# Altium schematic sheet sEMG_Microcontroller.SchDoc
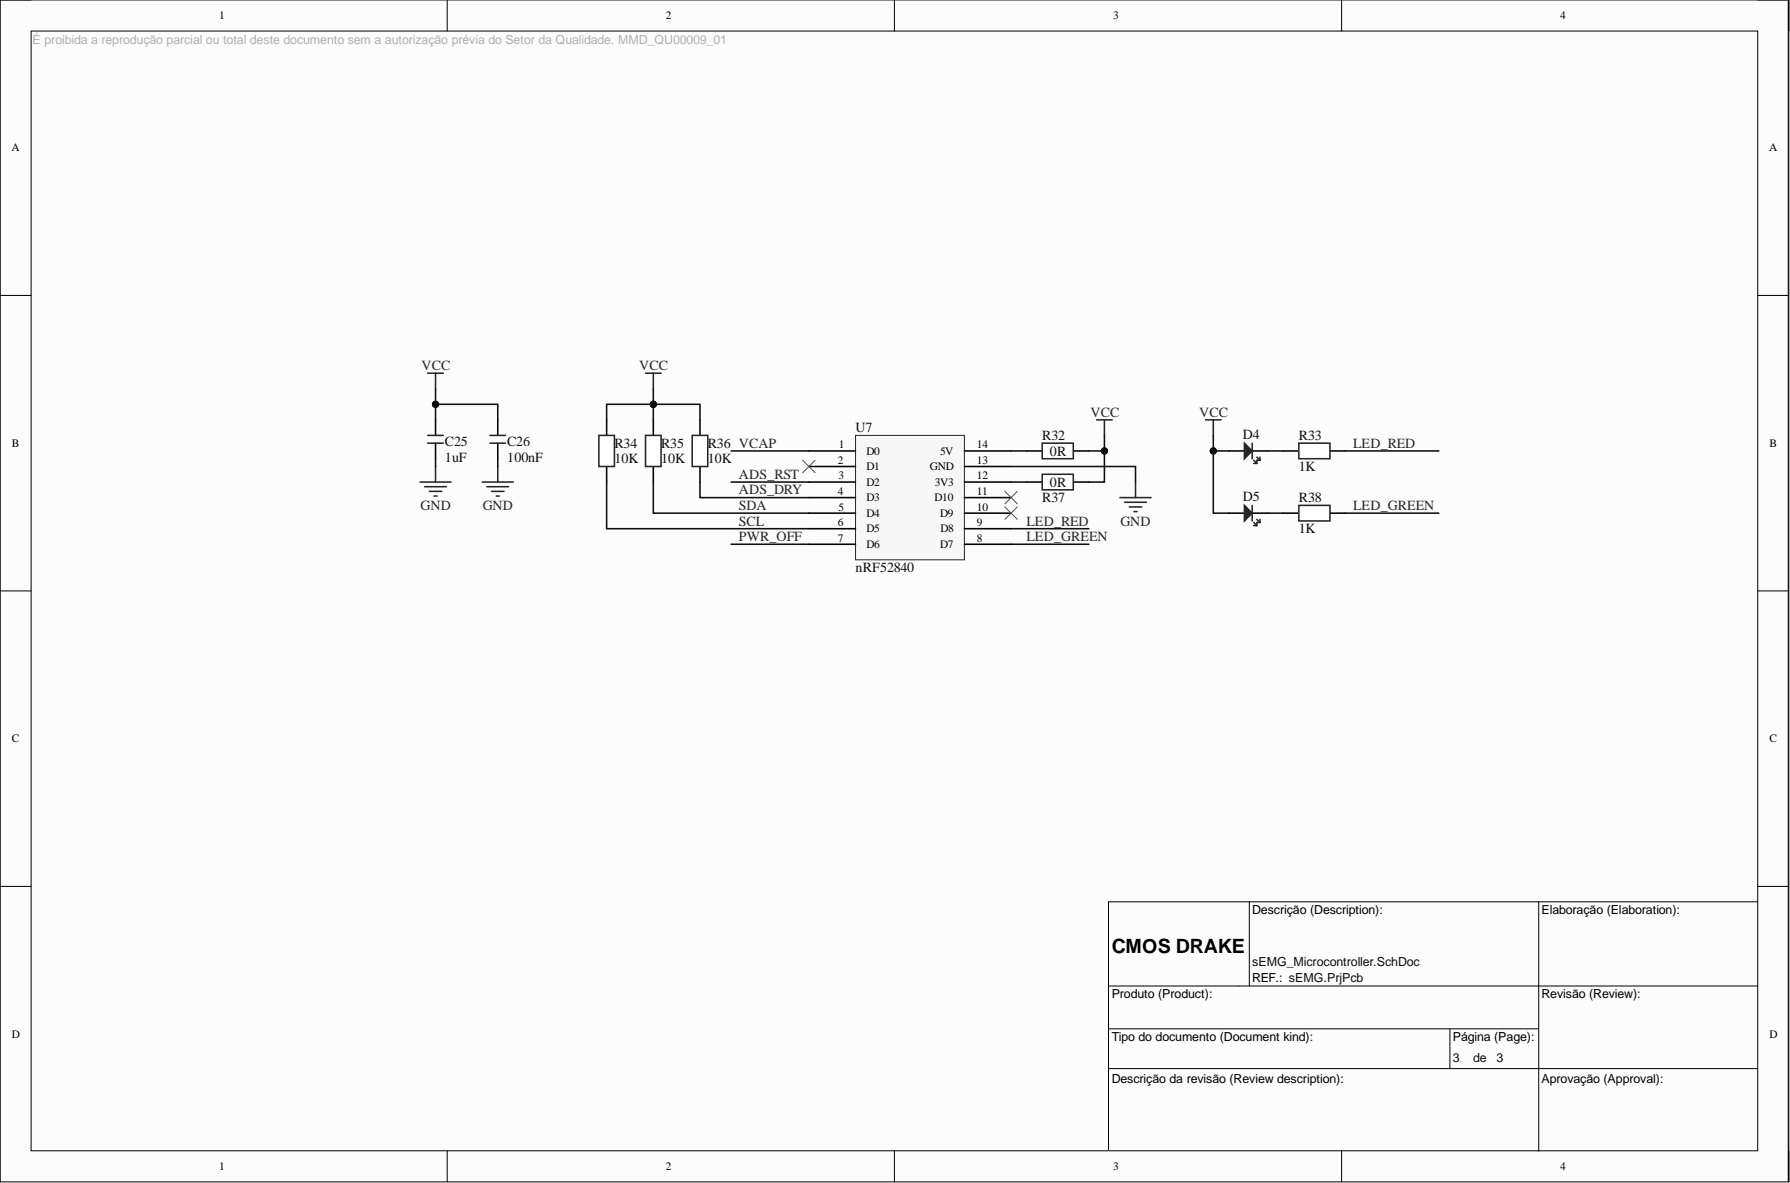
[diagram p0: sEMG_Microcontroller.SchDoc]

## Parts
C25  1uF  [CAP0402[1005]MD]
C26  100nF  [CAP0603[1608]MD-BO]
D4  (no value)  [LEDP0603RED-BO]
D5  (no value)  [LEDP0603GREEN-BO]
R32  0R  [RES0603[1608]MD-BO]
R33  1K  [RES0805[2012]MD]
R34  10K  [RES0805[2012]MD]
R35  10K  [RES0805[2012]MD]
R36  10K  [RES0805[2012]MD]
R37  0R  [RES0603[1608]MD-BO]
R38  1K  [RES0805[2012]MD]
U7  nRF52840  [PCBComponent_1]

## Nets
GND: C25.2 C26.2 U7.13(GND)
VCC: C25.1 C26.1 D4.2 D5.2 R32.2 R34.1 R35.1 R36.1 R37.2
ADS_DRY: R36.2 U7.4(D3)
ADS_RST: U7.3(D2)
LED_GREEN: R38.2 U7.8(D7)
LED_RED: R33.2 U7.9(D8)
PWR_OFF: U7.7(D6)
SCL: R34.2 U7.6(D5)
SDA: R35.2 U7.5(D4)
VCAP: U7.1(D0)
NetD4_1: D4.1 R33.1
NetD5_1: D5.1 R38.1
NetR32_1: R32.1 U7.14(5V)
NetR37_1: R37.1 U7.12(3V3)

Not connected: U7 2(D1) 10(D9) 11(D10)

## Library data of the parts
C25 = SMD 0402 1uF 16V 10% X5R: CERAMIC SMD 0402 1uF 16V 10% X5R; Manufacturer 1: TDK; Part Number 1: C1005X5R1C105K; Component Kind: Standard; Library Name: Capacitors.SCHLIB; Manufacturer 2: Taiyo Yuden; Manufacturer 3: AVX; Part Number 2: EMK105BJ105KV-F; Part Number 3: 0402YD105KAT2A
C26 = SMD 0603 100nF 50V 5% X7R: CERAMIC SMD 0603 100nF 50V 5% X7R; Manufacturer 1: Samsung Electro-Mechanics America, Inc.; Part Number 1: CL10B104JB8NNNC; Component Kind: Standard; Library Name: Capacitors.SCHLIB; Manufacturer 2: Yageo; Manufacturer 3: AVX Corporation; Manufacturer 4: Murata Electronics North America; Manufacturer 5: KEMET; Part Number 2: CC0603JRX7R9BB104; Part Number 3: 06035C104JAT2A; Part Number 4: GRM188R71H104JA93D; Part Number 5: C0603C104J5RACTU
D4 = LED VERMELHO SMD 0603 CLR: LED RED CLEAR 0603 SMD; Manufacturer 1: Kingbright Corp; Part Number 1: APT1608EC; Component Kind: Standard; Library Name: Diodes.SchLib
D5 = LED GREEN SMD 0603 2.1Vf@20mA CLR: Manufacturer 1: Lite-On Inc.; Part Number 1: LTST-S270GKT; Component Kind: Standard; Description: LED GREEN SMD 0603 2.1Vf@20mA CLR; Library Name: Diodes.SchLib
R32 R37 = SMD 0603 0R 1A 0.1W: RESISTOR SMD 0603 0R 0.1W; Manufacturer 1: Vishay; Part Number 1: RC0603JR-070RL; Component Kind: Standard; Library Name: Resistors.SCHLIB; Manufacturer 2: Stackpole Electronics Inc.; Manufacturer 3: Bourns Inc.; Manufacturer 4: TE Connectivity Passive Product; Part Number 2: RMCF0603ZT0R00; Part Number 3: CR0603-J/-000ELF; Part Number 4: CRG0603ZR
R33 R38 = SMD 0805 10K 5% 0.125W: RESISTOR SMD 0805 1K 5% 0.25W; Manufacturer 1: Yageo; Part Number 1: RC0805JR-0710KL; Component Kind: Standard; Library Name: Resistors.SCHLIB; Manufacturer 2: Samsung Electro-Mechanics America, Inc; Manufacturer 3: Stackpole Electronics Inc; Manufacturer 4: Rohm Semiconductor; Part Number 2: RC2012J103CS; Part Number 3: RMCF0805JT10K0; Part Number 4: MCR10ERTJ103
R34-R36 = SMD 0805 10K 5% 0.125W: RESISTOR SMD 0805 10K 1% 0.25W; Manufacturer 1: Yageo; Part Number 1: RC0805JR-0710KL; Component Kind: Standard; Library Name: Resistors.SCHLIB; Manufacturer 2: Samsung Electro-Mechanics America, Inc; Manufacturer 3: Stackpole Electronics Inc; Manufacturer 4: Rohm Semiconductor; Part Number 2: RC2012J103CS; Part Number 3: RMCF0805JT10K0; Part Number 4: MCR10ERTJ103
U7 = nRF52840: Component Kind: Standard; Description: nRF52840; Library Name: nRF52840.SchLib
